# Altium schematic sheet CAN BUS.SchDoc("CAN BUS")
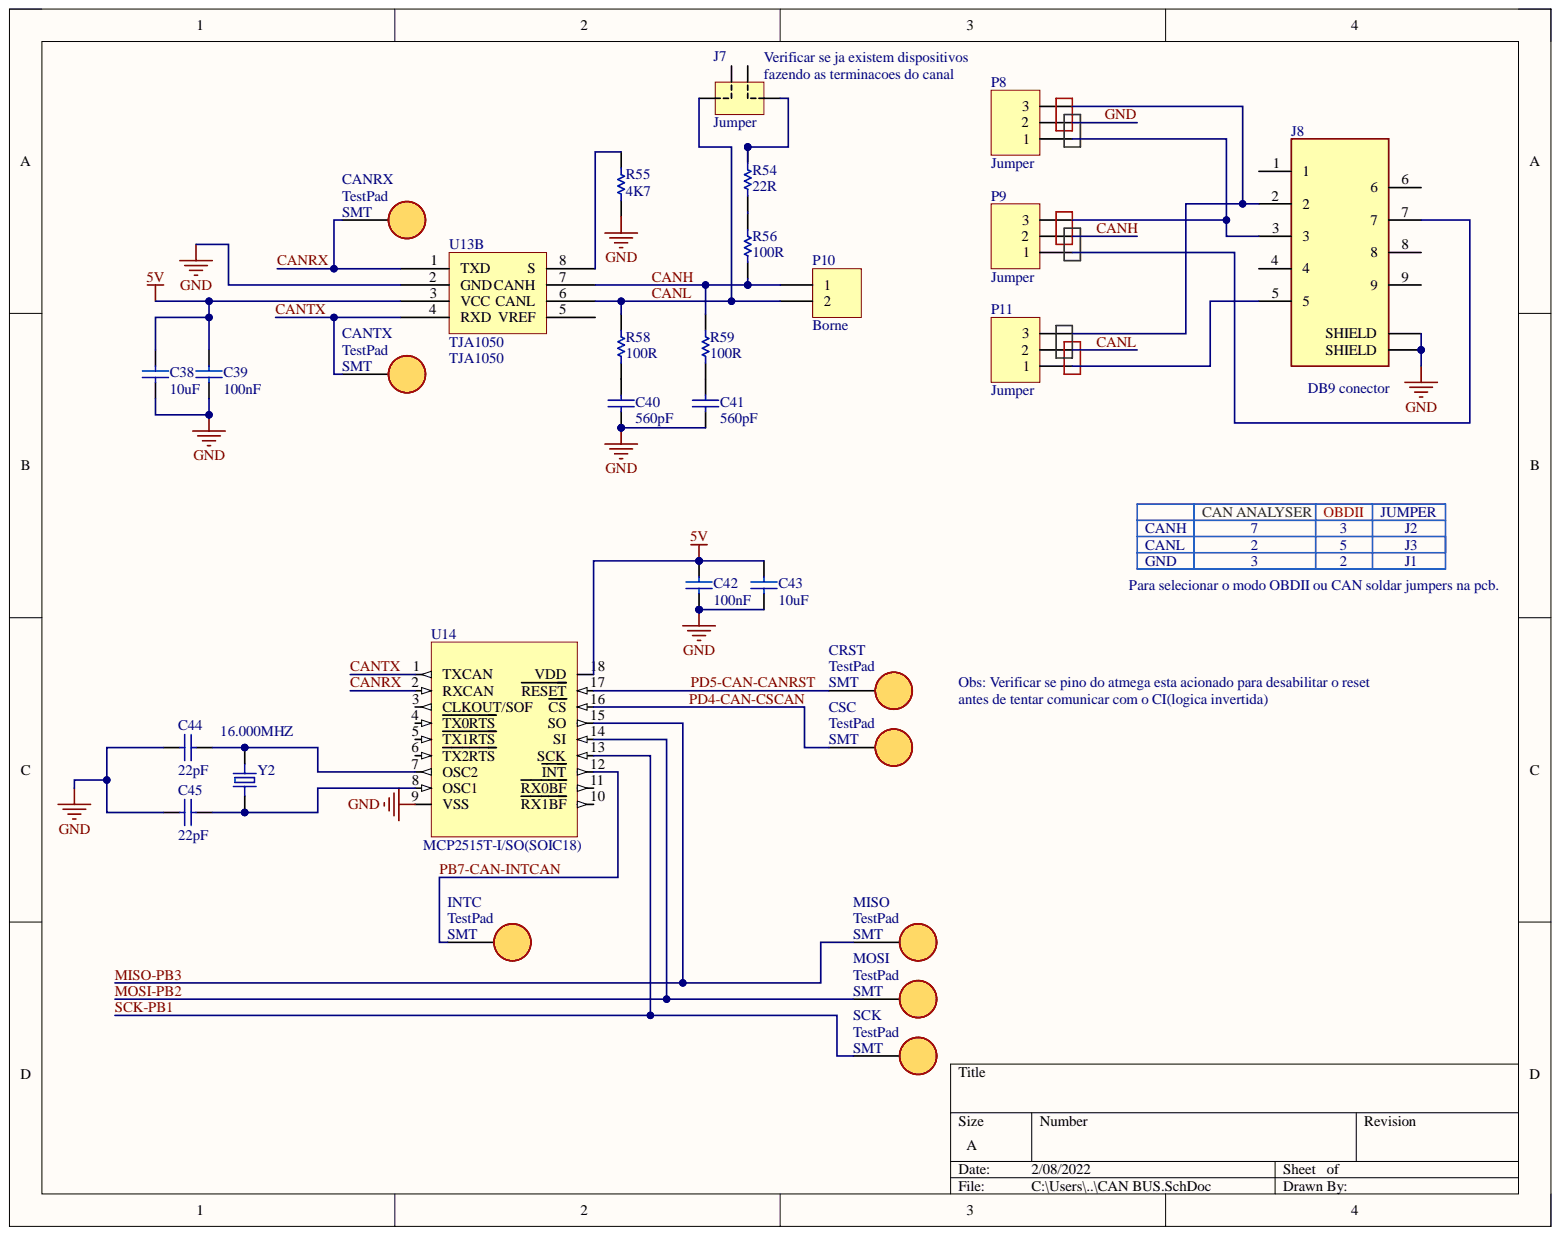
[diagram p0: CAN BUS.SchDoc]

## Parts
C38  10uF  [CAPGC2012X65N]
C39  100nF  [CAPC2012X65N]
C40  560pF  [CAPC2012X65N]
C41  560pF  [CAPC2012X65N]
C42  100nF  [CAPC2012X65N]
C43  10uF  value 100nF  [CAPGC2012X65N]
C44  22pF  [CAPC2012X65N]
C45  22pF  [CAPC2012X65N]
CANRX  (no value)  [TestPAD-SMT-SIMPLES]
CANTX  (no value)  [TestPAD-SMT-SIMPLES]
CRST  (no value)  [TestPAD-SMT-SIMPLES]
CSC  (no value)  [TestPAD-SMT-SIMPLES]
INTC  (no value)  [TestPAD-SMT-SIMPLES]
J7  Jumper  [SIP2]
J8  5747840-4  [TE_5747840-4]  (value not drawn)
MISO  (no value)  [TestPAD-SMT-SIMPLES]
MOSI  (no value)  [TestPAD-SMT-SIMPLES]
P8  Jumper  [Solder Joint 3 conect]
P9  Jumper  [Solder Joint 3 conect]
P10  Borne  [PCBComponent_1]
P11  Jumper  [Solder Joint 3 conect]
R54  22R  [RESC2012X65N]
R55  4K7  [RESC2012X65N]
R56  100R  [RESC2012X65N]
R58  100R  [RESC2012X65N]
R59  100R  [RESC2012X65N]
SCK  (no value)  [TestPAD-SMT-SIMPLES]
U13  TJA1050  [SOIC127P600X175-8N]  (part B)
U14  MCP2515T-I/SO(SOIC18)  [SOIC18-1.27-11.5X7.5MM]
Y2  16.000MHZ  [XTAL_ABLS-20.000MHZ-B4-T]

## Nets
5V: C38.1 C39.2 C42.1 C43.1 U13.3(VCC) U14.18(VDD)
CANH: P9.2 P10.1 R56.2 R59.1 U13.7(CANH)
CANL: J7.1 P10.2 P11.2 R58.1 U13.6(CANL)
CANRX: CANRX.1 U13.1(TXD) U14.2(RXCAN)
CANTX: CANTX.1 U13.4(RXD) U14.1(TXCAN)
GND: C38.2 C39.1 C40.1 C41.1 C42.2 C43.2 C44.2 C45.2 J8.SH1(SHIELD) J8.SH2(SHIELD) P8.2 R55.2 U13.2(GND) U14.9(VSS)
MISO-PB3: MISO.1 U14.15(SO)
MOSI-PB2: MOSI.1 U14.14(SI)
PB7-CAN-INTCAN: INTC.1 U14.12(INT)
PD4-CAN-CSCAN: CSC.1 U14.16(CS)
PD5-CAN-CANRST: CRST.1 U14.17(RESET)
SCK-PB1: SCK.1 U14.13(SCK)
NetC40_2: C40.2 R58.2
NetC41_2: C41.2 R59.2
NetC44_1: C44.1 U14.7(OSC2) Y2.1
NetC45_1: C45.1 U14.8(OSC1) Y2.2
NetJ7_2: J7.2 R54.1
NetJ8_2: J8.2 P8.3 P11.3
NetJ8_3: J8.3 P8.1 P9.3
NetJ8_5: J8.5 P11.1
NetJ8_7: J8.7 P9.1
NetR54_2: R54.2 R56.1
NetR55_1: R55.1 U13.8(S)

Not connected: J8 1 4 6 8 9; U13 5(VREF); U14 3(CLKOUT/SOF) 4(TX0RTS) 5(TX1RTS) 6(TX2RTS) 10(RX1BF) 11(RX0BF)

## Library data of the parts
C38 C40 C41 C44 C45 = Cap: Capacitor; Value: 100nF; Component Kind: Standard; LatestRevisionDate: 17-Jul-2002; LatestRevisionNote: Re-released for DXP Platform.; Library Name: Miscellaneous Devices.IntLib; PackageDescription: Radial Cap, Thru-Hole; 2 Leads; 0.3 in Pin Spacing; PackageReference: RAD-0.3; Published: 8-Jun-2000; Publisher: Altium Limited
C39 C42 C43 = Cap: Capacitor; Component Kind: Standard; LatestRevisionDate: 17-Jul-2002; LatestRevisionNote: Re-released for DXP Platform.; Library Name: Miscellaneous Devices.IntLib; PackageDescription: Radial Cap, Thru-Hole; 2 Leads; 0.3 in Pin Spacing; PackageReference: RAD-0.3; Published: 8-Jun-2000; Publisher: Altium Limited
  C39 C42: Value: 100nF
CANRX CANTX CRST CSC INTC MISO MOSI SCK = TestPad: Component Kind: Standard; Library Name: TestPadSMT.SchLib
J7 = JP2: Component Kind: Standard; Library Name: Zele_Sch.SchLib
J8 = 5747840-4: Connector D-Subminiature Plug 9 Position 2.74mm Solder Right Angle Through Hole 9 Terminal 1 Port; Centerline_Pitch: 2.74 mm[.108 in]; MF: TE Connectivity; Number_of_Positions: 9; Component Kind: Standard; Digi-Key_Part_Number: A32092-ND; Digi-Key_Purchase_URL: https://www.digikey.de/product-detail/en/te-connectivity-amp-connectors/5747840-4/A32092-ND/808647?utm_source=snapeda&utm_medium=aggregator&utm_campaign=symbol; EU_RoHS_Compliance: Compliant; Library Name: ConectorDB9.SchLib; MP: 5747840-4; Package: None; Product_Type: Connector; SnapEDA_Link: https://www.snapeda.com/parts/5747840-4/TE%20Connectivity%20AMP%20Connectors/view-part/550949/?ref=snap; TE_purchase_URL: https://www.te.com/usa-en/product-5747840-4.html?te_bu=Cor&te_type=disp&te_campaign=seda_glo_cor-seda-global-disp-prtnr-fy19-seda-model-bom-cta_sma-317_1&elqCampaignId=32493
P8 P9 P11 = Header 3: Header, 3-Pin; Component Kind: Standard; LatestRevisionDate: 17-Jul-2002; LatestRevisionNote: Re-released for DXP Platform.; Library Name: Miscellaneous Connectors.IntLib; Signal Integrity: Connector; Publisher: Altium Limited
P10 = Header 2: Header, 2-Pin; Component Kind: Standard; LatestRevisionDate: 17-Jul-2002; LatestRevisionNote: Re-released for DXP Platform.; Library Name: Miscellaneous Connectors.IntLib; Signal Integrity: Connector; Publisher: Altium Limited
R54-R56 R58 R59 = Res1: Resistor; Value: 47K; Component Kind: Standard; LatestRevisionDate: 17-Jul-2002; LatestRevisionNote: Re-released for DXP Platform.; Library Name: Miscellaneous Devices.IntLib; PackageDescription: Axial Device, Thru-Hole; 2 Leads; 0.3 in Pin Spacing; PackageReference: AXIAL-0.3; Published: 8-Jun-2000; Signal Integrity: Res; Simulation: RESISTOR; Publisher: Altium Limited
U14 = INTERFACE-MCP2515T-I/SO(SOIC18): IC CAN CONTROLLER W/SPI 18SOIC; MF: Microchip Technology; Availability: Unavailable; Component Kind: Standard; Ibis Model: MCP2518FD; Library Name: MCP2515T-I_SO(SOIC18).SchLib; MP: MCP2515T-I/SO; Package: None; Price: None; SnapEDA_Link: https://www.snapeda.com/parts/MCP2515T-I/SO/Atmel/view-part/4793276/?ref=snap
Y2 = ABLS-20.000MHZ-B4-T: 20MHz Â±30ppm Crystal 18pF 40 Ohms HC-49/US; MF: Abracon LLC; Availability: Unavailable; Component Kind: Standard; MP: ABLS-20.000MHZ-B4-T; Package: HC-49/US Abracon LLC; Price: None; SnapEDA_Link: https://www.snapeda.com/parts/ABLS-20.000MHZ-B4-T/Abracon%20LLC/view-part/383704/?ref=snap; Library Name: Resonador16Mhz.SchLib
U13 = TJA1050: Component Kind: Standard; Library Name: TJA1050.SchLib
